# Altium schematic sheet Top_Level.SchDoc(Top_Level)
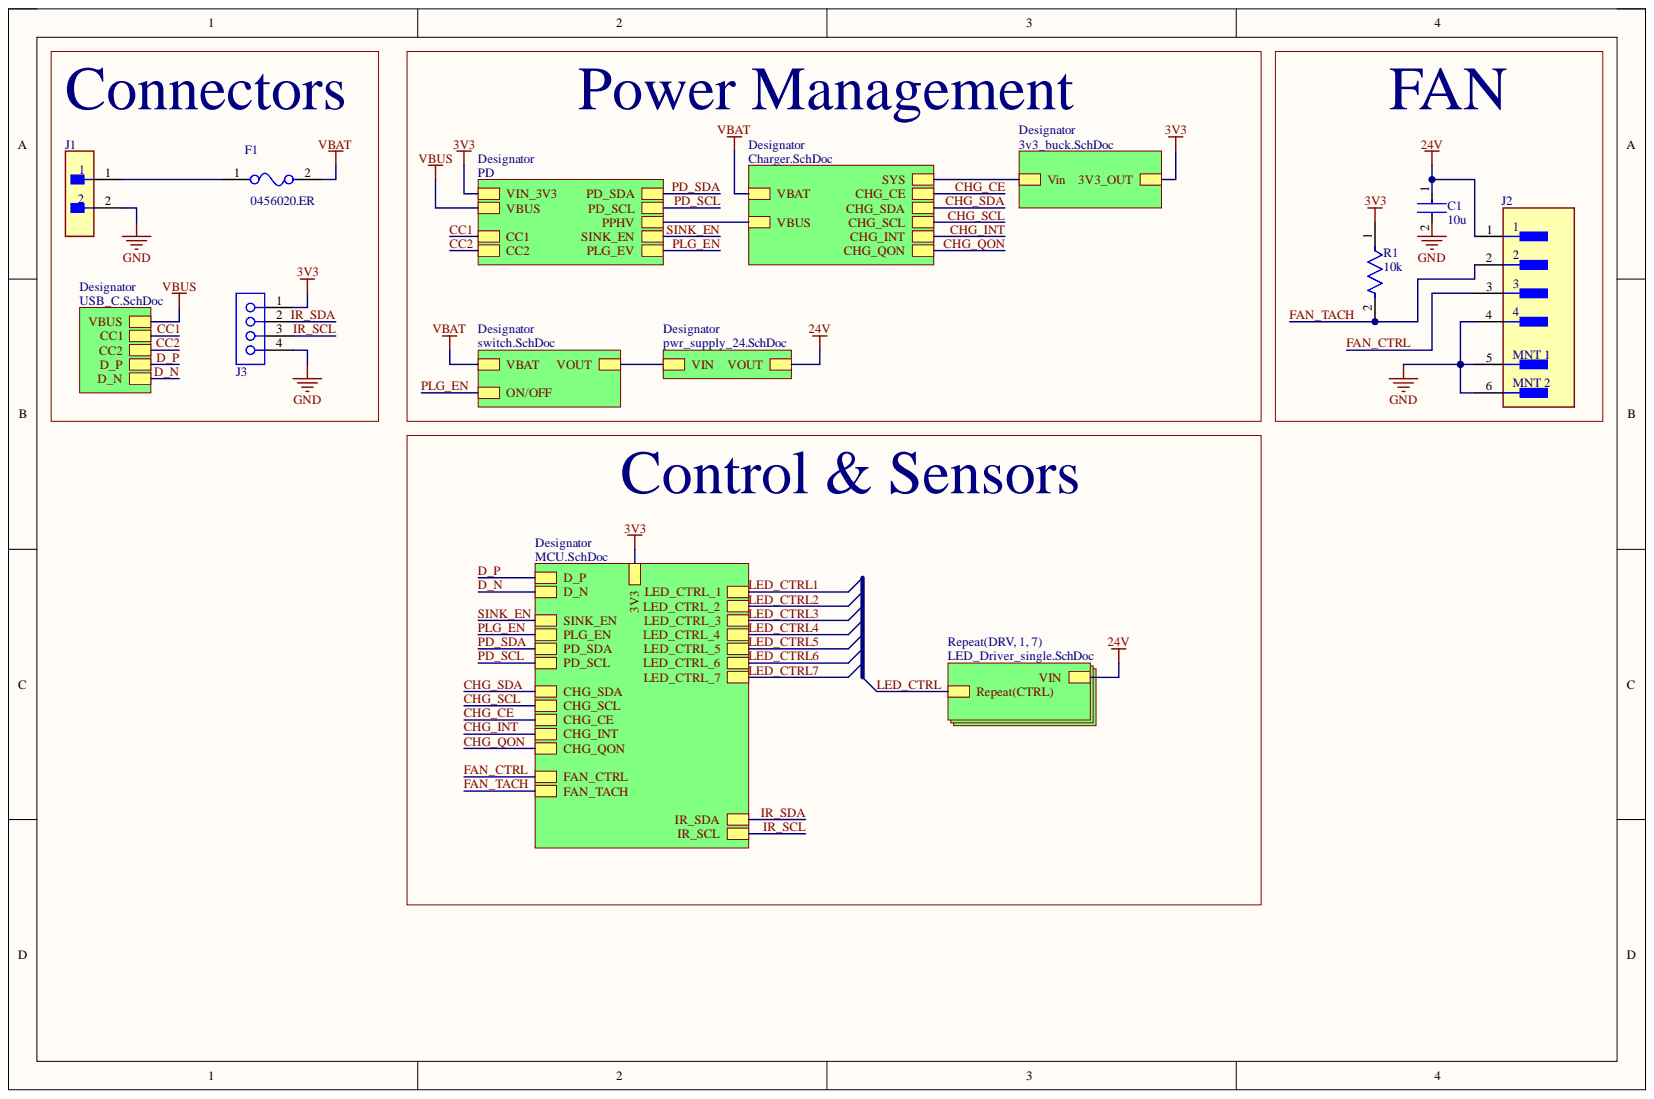
[diagram p0: Top_Level.SchDoc]

## Parts
C1  10u  [FP-CC1210-GD-MFG]
F1  0456020.ER  [FP-0456-MFG]
J1  B2P-VH-FB-B(LF)(SN)  [FP-B2P-VH-FB-B_LF_SN-MFG]  (value not drawn)
J2  B4B-PH-SM4-TBT(LF)(SN)  [FP-B4B-PH-SM4-TBT_LF_SN-MFG]  (value not drawn)
J3  39-53-2044  [MOLX-39-53-2044]  (value not drawn)
R1  10k  [FP-RT0603-MFG]

## Nets
3V3: J3.1 R1.1
24V: C1.1 J2.1
GND: C1.2 J1.2 J2.4 J2.5 J2.6 J3.4
VBAT: F1.2
FAN_CTRL: J2.3
FAN_TACH: J2.2 R1.2
IR_SCL: J3.3
IR_SDA: J3.2
NetF1_1: F1.1 J1.1
CC1:
CC2:
CHG_CE:
CHG_INT:
CHG_QON:
CHG_SCL:
CHG_SDA:
D_N:
D_P:
LED_CTRL1:
LED_CTRL2:
LED_CTRL3:
LED_CTRL4:
LED_CTRL5:
LED_CTRL6:
LED_CTRL7:
PD_SCL:
PD_SDA:
PLG_EN:
PPHV:
SINK_EN:
SYS:
VBUS:
VIN:

## Library data of the parts
C1 = CMP-03422-001447-1: CAP CER 10UF 50V X7R 1210 CC1210MKX7R9BB106; Tolerance: 20%; Capacitance: 10uF; Case Code (Imperial): 1210; Case Code (Metric): 3225; Case/Package: 1210; Component Kind: Standard; Library Name: Altium Content Vault; Max Operating Temperature: 125°C; Min Operating Temperature: -55°C; Mount: Surface Mount; Packaging: Tape and Reel; RoHS Compliant: Yes; Voltage Rating: 50V
F1 = CMP-08605-000068-1: FUSE BOARD MNT 20A 125VAC 100VDC; Manufacturer: Littelfuse Inc; Fuse Blow Speed: Fast; Fuse I2T: 18A2Sec; Fuse Interrupt Ac Current: 300A; Fuse Interrupt Dc Current: 1000A; Fuse Voltage Drop: 64.7mV; Max Fuse Ac Voltage: 125V; Max Fuse Dc Voltage: 100V; Resistance: 2.3mΩ; Value: 2.3mΩ; Automotive: No; Category: Fuse; Component Kind: Standard; ComponentLink2Description: Datasheet; ComponentLink2URL: https://www.littelfuse.com/~/media/electronics/datasheets/fuses/littelfuse_fuse_456_datasheet.pdf.pdf; Device Class L1: Circuit Protection; Device Class L2: Fuses; Device Class L3: unset; Digikey Description: FUSE BOARD MNT 20A 125VAC 100VDC; Fuse Current: 20A; Height: 3.05mm; Lead Free: Yes; Library Name: Altium Content Vault; Manufacturer Part Number: 0456020.ER; Mouser Description: Surface Mount Fuses 125V 20A V/FA NANO2; Octopart: https://octopart.com/0456020.er-littelfuse-7502596; Package: 0456; RoHS: TRUE; Temperature: -55°C to +125°C; Temperature Range High: +125°C; Temperature Range Low: -55°C; Voltage Rating: 125V
J1 = CMP-17439-000031-1: CONN HEADER VERT 2POS 3.96MM; Connector Type: Male Pin; Number of Positions: 2; Pitch: 0.156inch; Color: Natural; Component Kind: Standard; Contact Plating: Tin; Contact Type: Male Pin; Fastening Type: Ramp; Lead Free: Lead Free; Library Name: Altium Content Vault; Mount: Through Hole; Number of Rows: 1; Package Quantity: 250; Packaging: Bulk; Series: VH; Termination: Solder
J2 = CMP-17439-000271-1: CONN HEADER SMD 4POS 2MM; Contact Resistance: 20mR; Mated Stacking Heights: 8.6mm; Max Current Rating: 2A; Max Voltage Rating (AC): 100V; Number of Positions: 4; Color: Natural; Component Kind: Standard; Connector Type: Header; Contact Finish - Mating: TIN; Contact Material: Copper Alloy; Contact Mating Length: 0.13inch; Contact Plating: Copper; Contact Shape: Square; Contact Type: Male Pin; Depth: 5mm; Fastening Type: Detent; Flammability Rating: UL94 V-0; Housing Color: Beige; Housing Material: Polyamide; Insulation Color: Natural; Insulation Material: Nylon; Lead Free: Lead Free; Length: 11.95mm; Library Name: Altium Content Vault; Max Operating Temperature: 85°C; Min Operating Temperature: -25°C; Mount: Surface Mount; Number of Rows: 1; Orientation: Straight; Package Quantity: 1; Packaging: Tape and Reel; Pitch: 2mm; Series: PH; Termination: Solder; Voltage Rating: 100V
J3 = CMP-1242-00003-1: 1.25 mm Pitch FPC Connector, 5.0 mm Mated Height, Right Angle, ZIF, Through Hole, Top Contact, 4 Circuits, -20 to 80 degC, ELV and RoHS Compliant, Tray; Manufacturer: Molex; Circuits (Loaded): 4; Current (Max per Contact): 1A; Durability (mating cycles max): 30; Entry Angle: 90degrees Angle; Mated Height: 5.00mm; Material - Metal: Phosphor Bronze; Material - Plating Mating: Tin-Bismuth; PCB Locator: No; PCB Retention: None; Pitch - Mating Interface: 1.25mm; Voltage (Max): 200V; Component Kind: Standard; ComponentLink1Description: Manufacturer URL; ComponentLink1URL: http://www.molex.com/molex/index.jsp; ComponentLink2Description: Datasheet; ComponentLink2URL: http://www.molex.com/webdocs/datasheets/pdf/en-us/0039532044_FFC_FPC_CONNECTORS.pdf; ComponentLink3Description: Package Specification; ComponentLink3URL: http://www.molex.com/pdm_docs/sd/039532044_sd.pdf; Contact Position: Top; Library Name: Altium Content Vault; Material - Plating Termination: Tin-Bismuth; Mounting Technology: Through Hole; Number of Rows: 1; Orientation: Right Angle; PackageDescription: 4-Lead FPC Connector, Pitch 1.25 mm; PackageReference: 39-53-2044; PackageVersion: Rev. B, 04/2007; Packing: Tray; PartNumber: 39-53-2044; Polarized to PCB: No; RoHS: TRUE; Stackable: No
R1 = CMP-03412-024264-1: RT0603 10KΩ 0.1W 50 ppm/°C 1.00% RT0603FRE1010KL; Manufacturer: Yageo; Tolerance: 0.01; Voltage Rating: 75V; Automotive: No; Category: Res; Component Kind: Standard; ComponentLink2Description: Datasheet; ComponentLink2URL: http://www.yageo.com/documents/recent/PYu-RT_1-to-0.01_RoHS_L_9.pdf; Composition: Thin Film; Device Class L1: Passive Components; Device Class L2: Resistors; Device Class L3: Chip SMD Resistors; Footprint Url: http://www.yageo.com/exep/pages/download/literatures/PYu-R_Mount_10.pdf; Height: 0.55mm; Ipc Land Pattern Name: RESC16080X45; Lead Free: Yes; Library Name: Altium Content Vault; Manufacturer Part Number: RT0603FRE1010KL; Octopart: https://octopart.com/rt0603fre1010kl-yageo-26185585; Package: RT0603; Power Rating: 0.1W; Resistance: 10KΩ; RoHS: TRUE; Series: RT; Temperature: -55°C to +155°C; Temperature Coefficient: 50 ppm/°C; Temperature Range High: +155°C; Temperature Range Low: -55°C; Value: 10KΩ
